# Altium schematic sheet FPGA_MISC.SchDoc(FPGA_MISC)
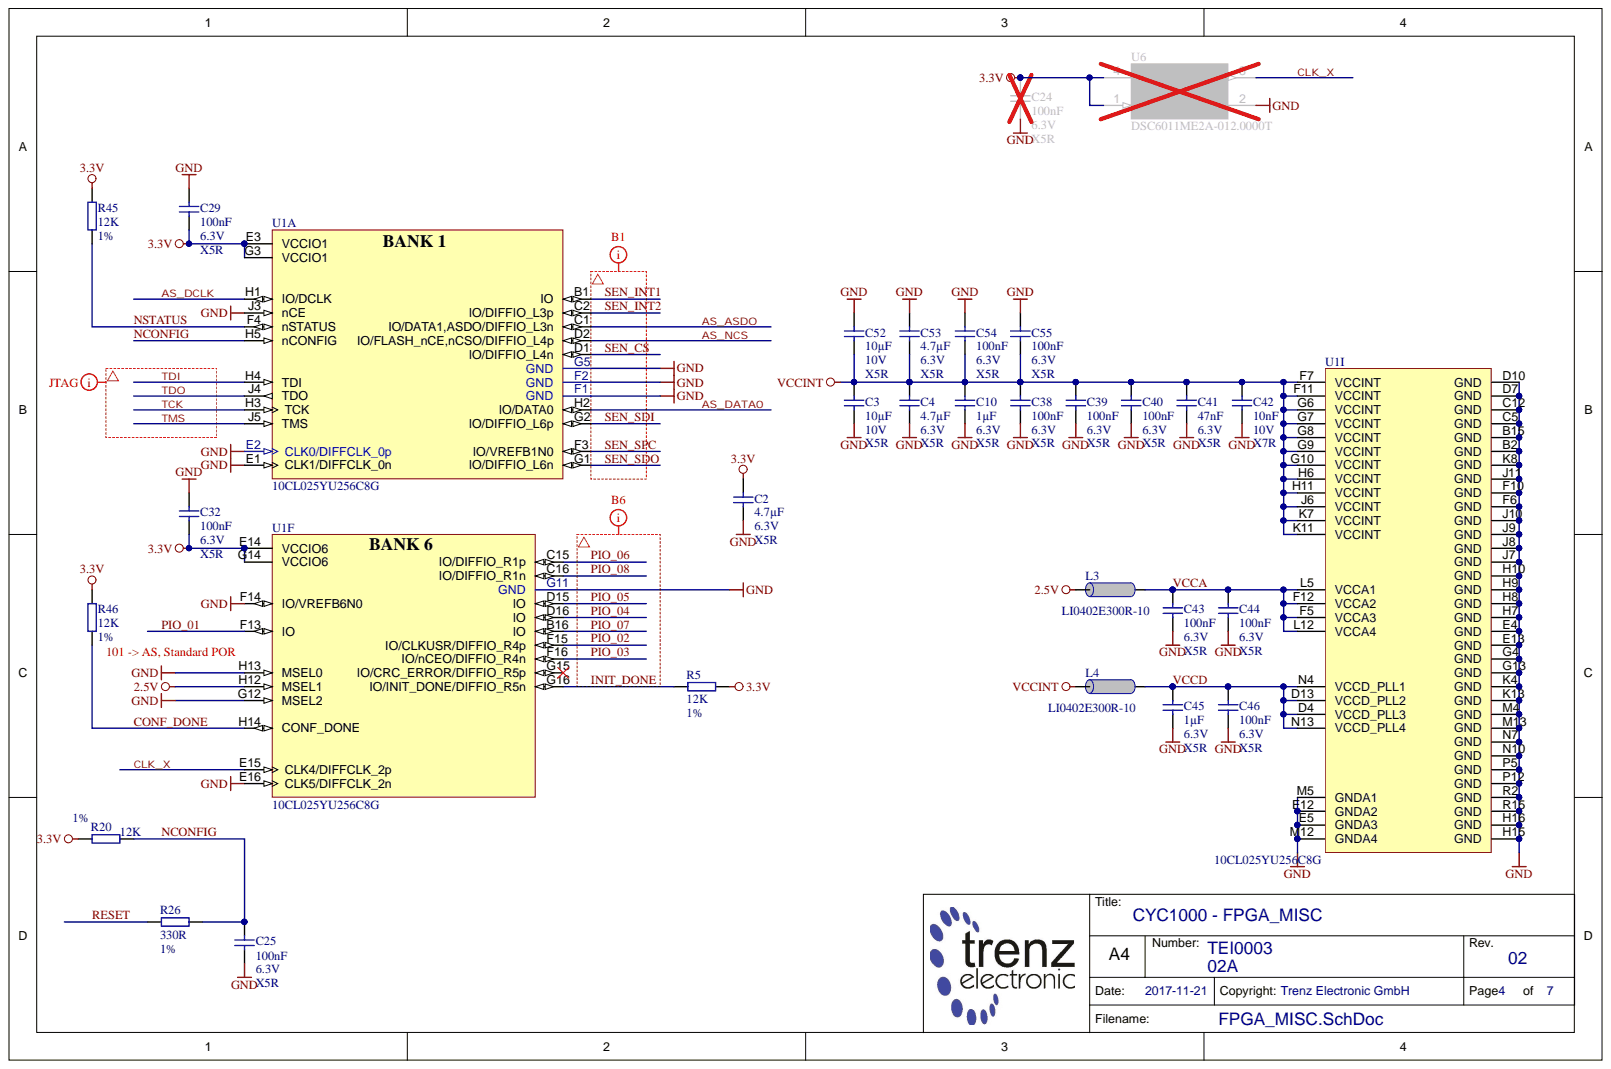
[diagram p0: FPGA_MISC.SchDoc]

## Parts
C2  4.7µF  Technology: X5R  Voltage: 6.3V  [CAPC1005X60N]
C3  10µF  Technology: X5R  Voltage: 10V  [CAPC2012X135N]
C4  4.7µF  Technology: X5R  Voltage: 6.3V  [CAPC1005X60N]
C10  1µF  Technology: X5R  Voltage: 6.3V  [CAPC1005X55N]
C24  100nF  Technology: X5R  Voltage: 6.3V  [CAPC0603X33N]
C25  100nF  Technology: X5R  Voltage: 6.3V  [CAPC0603X33N]
C29  100nF  Technology: X5R  Voltage: 6.3V  [CAPC0603X33N]
C32  100nF  Technology: X5R  Voltage: 6.3V  [CAPC0603X33N]
C38  100nF  Technology: X5R  Voltage: 6.3V  [CAPC0603X33N]
C39  100nF  Technology: X5R  Voltage: 6.3V  [CAPC0603X33N]
C40  100nF  Technology: X5R  Voltage: 6.3V  [CAPC0603X33N]
C41  47nF  Technology: X5R  Voltage: 6.3V  [CAPC0603X33N]
C42  10nF  Technology: X7R  Voltage: 10V  [CAPC0603X33N]
C43  100nF  Technology: X5R  Voltage: 6.3V  [CAPC0603X33N]
C44  100nF  Technology: X5R  Voltage: 6.3V  [CAPC0603X33N]
C45  1µF  Technology: X5R  Voltage: 6.3V  [CAPC1005X55N]
C46  100nF  Technology: X5R  Voltage: 6.3V  [CAPC0603X33N]
C52  10µF  Voltage: 10V  [CAPC2012X135N]
C53  4.7µF  Voltage: 6.3V  [CAPC1005X60N]
C54  100nF  Voltage: 6.3V  [CAPC0603X33N]
C55  100nF  Voltage: 6.3V  [CAPC0603X33N]
L3  LI0402E300R-10  [INDC1005X55N]
L4  LI0402E300R-10  [INDC1005X55N]
R5  12K  Tolerance: 1%  [RESC1005X40N]
R20  12K  Tolerance: 1%  [RESC1005X40N]
R26  330R  Tolerance: 1%  [RESC1005X40N]
R45  12K  Tolerance: 1%  [RESC1005X40N]
R46  12K  Tolerance: 1%  [RESC1005X40N]
U1  10CL025YU256C8G  [BGA256C80_1400X1400X150B50]  (parts A,F,I)
U6  DSC6011ME2A-012.0000T  [2016]

## Nets
2.5V: L3.2 U1.H12(MSEL1)
3.3V: C2.1 C24.1 C29.2 C32.2 R5.1 R20.1 R45.1 R46.1 U1.E3(VCCIO1) U1.E14(VCCIO6) U1.G3(VCCIO1) U1.G14(VCCIO6) U6.1(OE/ST/FS) U6.4(VDD)
GND: C2.2 C3.2 C4.2 C10.2 C24.2 C25.2 C29.1 C32.1 C38.2 C39.2 C40.2 C41.2 C42.2 C43.2 C44.2 C45.2 C46.2 C52.2 C53.2 C54.2 C55.2 U1.B2(GND) U1.B15(GND) U1.C5(GND) U1.C12(GND) U1.D7(GND) U1.D10(GND) U1.E1(CLK1/DIFFCLK_0n) U1.E2(CLK0/DIFFCLK_0p) U1.E4(GND) U1.E5(GNDA3) U1.E12(GNDA2) U1.E13(GND) U1.E16(CLK5/DIFFCLK_2n) U1.F1(GND) U1.F2(GND) U1.F6(GND) U1.F10(GND) U1.F14(IO/VREFB6N0) U1.G4(GND) U1.G5(GND) U1.G11(GND) U1.G12(MSEL2) U1.G13(GND) U1.H7(GND) U1.H8(GND) U1.H9(GND) U1.H10(GND) U1.H13(MSEL0) U1.H15(GND) U1.H16(GND) U1.J3(nCE) U1.J7(GND) U1.J8(GND) U1.J9(GND) U1.J10(GND) U1.J11(GND) U1.K4(GND) U1.K8(GND) U1.K13(GND) U1.M4(GND) U1.M5(GNDA1) U1.M12(GNDA4) U1.M13(GND) U1.N7(GND) U1.N10(GND) U1.P5(GND) U1.P12(GND) U1.R2(GND) U1.R15(GND) U6.2(GND)
VCCINT: C3.1 C4.1 C10.1 C38.1 C39.1 C40.1 C41.1 C42.1 C52.1 C53.1 C54.1 C55.1 L4.2 U1.F7(VCCINT) U1.F11(VCCINT) U1.G6(VCCINT) U1.G7(VCCINT) U1.G8(VCCINT) U1.G9(VCCINT) U1.G10(VCCINT) U1.H6(VCCINT) U1.H11(VCCINT) U1.J6(VCCINT) U1.K7(VCCINT) U1.K11(VCCINT)
AS_ASDO: U1.C1(IO/DATA1,ASDO/DIFFIO_L3n)
AS_DATA0: U1.H2(IO/DATA0)
AS_DCLK: U1.H1(IO/DCLK)
AS_NCS: U1.D2(IO/FLASH_nCE,nCSO/DIFFIO_L4p)
CLK_X: U1.E15(CLK4/DIFFCLK_2p) U6.3(OUT)
CONF_DONE: R46.2 U1.H14(CONF_DONE)
INIT_DONE: R5.2 U1.G16(IO/INIT_DONE/DIFFIO_R5n)
NCONFIG: C25.1 R20.2 R26.2 U1.H5(nCONFIG)
NSTATUS: R45.2 U1.F4(nSTATUS)
PIO_01: U1.F13(IO)
PIO_02: U1.F15(IO/CLKUSR/DIFFIO_R4p)
PIO_03: U1.F16(IO/nCEO/DIFFIO_R4n)
PIO_04: U1.D16(IO)
PIO_05: U1.D15(IO)
PIO_06: U1.C15(IO/DIFFIO_R1p)
PIO_07: U1.B16(IO)
PIO_08: U1.C16(IO/DIFFIO_R1n)
RESET: R26.1
SEN_CS: U1.D1(IO/DIFFIO_L4n)
SEN_INT1: U1.B1(IO)
SEN_INT2: U1.C2(IO/DIFFIO_L3p)
SEN_SDI: U1.G2(IO/DIFFIO_L6p)
SEN_SDO: U1.G1(IO/DIFFIO_L6n)
SEN_SPC: U1.F3(IO/VREFB1N0)
TCK: U1.H3(TCK)
TDI: U1.H4(TDI)
TDO: U1.J4(TDO)
TMS: U1.J5(TMS)
VCCA: C43.1 C44.1 L3.1 U1.F5(VCCA3) U1.F12(VCCA2) U1.L5(VCCA1) U1.L12(VCCA4)
VCCD: C45.1 C46.1 L4.1 U1.D4(VCCD_PLL3) U1.D13(VCCD_PLL2) U1.N4(VCCD_PLL1) U1.N13(VCCD_PLL4)
N00200:
N00201:
N00202:

Not connected: U1 G15(IO/CRC_ERROR/DIFFIO_R5p)

## Library data of the parts
C2 C4 C53 = 24719: KON 4.7µF 6.3V X5R SMD 20%; Database Table Name: C_1005_0402; Mixed: SMT; Preferred: Yes; Value: 4.7u; AN: 24719; Case: 1005 (0402); Component Kind: Standard; Library Name: TE.DbLib; Tolerance: 20%
  C53: Technology: X5R
C3 C52 = 28014: KON 10µF 10V X5R 10% SMD; Database Table Name: C_2012_0805; Mixed: SMT; Value: 10u; AN: 28014; Case: 2012 (0805); Component Kind: Standard; Library Name: TE.DbLib; Tolerance: 10%
  C52: Technology: X5R
C10 C45 = 23453: KON 1µF 6.3V X5R SMD 10%; Database Table Name: C_1005_0402; Mixed: SMT; Preferred: Yes; Value: 1u; AN: 23453; Case: 1005 (0402); Component Kind: Standard; Library Name: TE.DbLib; Tolerance: 10%
C24 C25 C29 C32 C38-C40 C43 C44 C46 C54 C55 = 24915: KON 100nF 6.3V X5R SMD 10%; Database Table Name: C_0603_0201; Mixed: SMT; Preferred: Yes; Value: 100n; AN: 24915; Case: 0603 (0201); Component Kind: Standard; Library Name: TE.DbLib; Tolerance: 10%
  C54 C55: Technology: X5R
C41 = 24924: KON 47nF X5R 6.3V SMD 10%; Database Table Name: C_0603_0201; Mixed: SMT; Preferred: Yes; Value: 47n; AN: 24924; Case: 0603 (0201); Component Kind: Standard; Library Name: TE.DbLib; Tolerance: 10%
C42 = 26312: KON 10nF X7R 10V SMD 10%; Database Table Name: C_0603_0201; Mixed: SMT; Preferred: Yes; Value: 10n; AN: 26312; Case: 0603 (0201); Component Kind: Standard; Library Name: TE.DbLib; Tolerance: 10%
L3 L4 = 24681: Ferritdurchführung LI0402E300R-10; Database Table Name: L_1005_0402; Mixed: SMT; Preferred: Yes; Voltage: 500mA; AN: 24681; Case: 1005 (0402); Component Kind: Standard; H-ART: LI0402E300R-10; Library Name: TE.DbLib; Technology: 0.3Ohm; HelpURL: B:\AD\adlib\datasheet\LI0402E300R-10
R5 R20 R45 R46 = 22598: WID SMD 12K 1% 0,063W; Database Table Name: R_1005_0402; Mixed: SMT; Preferred: Yes; AN: 22598; Case: 1005 (0402); Component Kind: Standard; Library Name: TE.DbLib; Technology: TK100; Value: 12K
R26 = 22762: WID SMD 330R 1% 0,063W; Database Table Name: R_1005_0402; Mixed: SMT; Preferred: Yes; Value: 330; AN: 22762; Case: 1005 (0402); Component Kind: Standard; Library Name: TE.DbLib; Technology: TK100
U1 = 28294: Database Table Name: PROG_LOGIC; Mixed: SMT; AN: 28294; Case: UBGA256; Component Kind: Standard; Description: 10CL025YU256C8G; H-ART: 10CL025YU256C8G; Library Name: TE.DbLib; HelpURL: B:\ad\adlib\datasheet\Altera_Cyclone
U6 = 28002: Database Table Name: CLK; Mixed: SMT; Value: 12M; AN: 28002; Case: 2.0 mm x 1.6 mm; Component Kind: Standard; Description: DSC6011ME2A-012.0000T; H-ART: DSC6011ME2A-012.0000T; Library Name: TE.DbLib; HelpURL: b:\AD\adlib\datasheet\MICROCHIP_DSC60XX\
